# Altium schematic sheet LED16_IPM.SchDoc
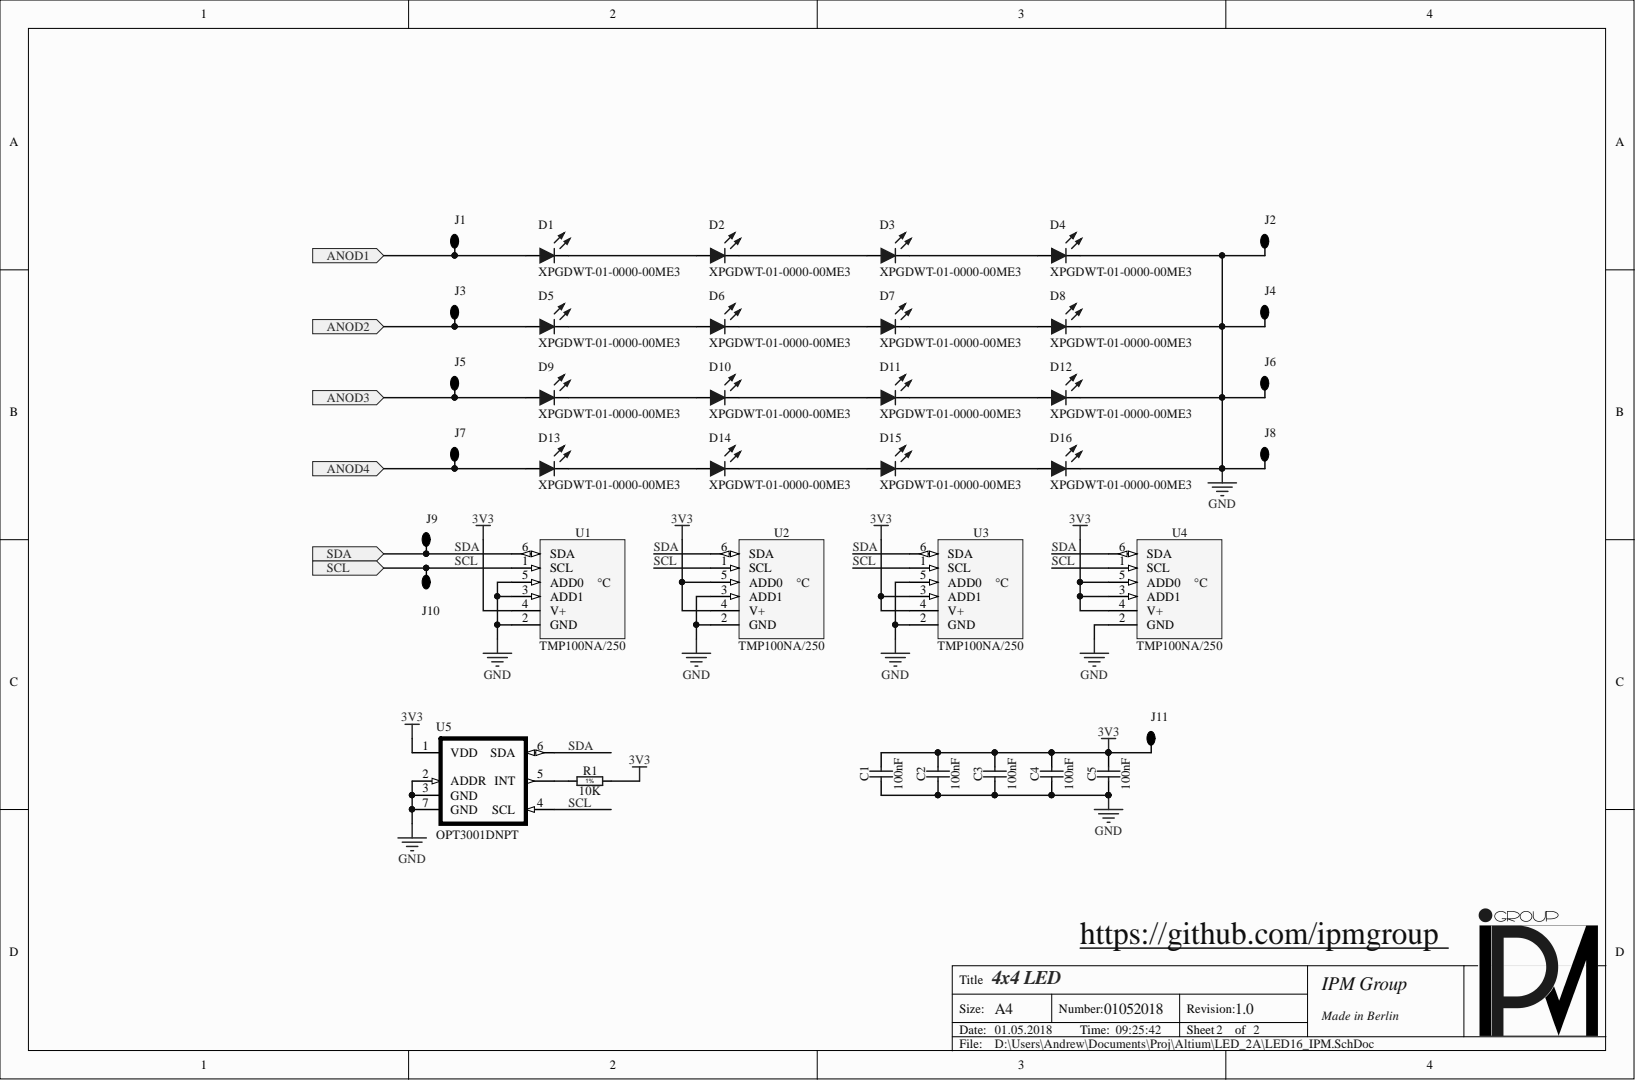
[diagram p0: LED16_IPM.SchDoc]

## Parts
C1  100nF  [CAPC1005X55N]
C2  100nF  [CAPC1005X55N]
C3  100nF  [CAPC1005X55N]
C4  100nF  [CAPC1005X55N]
C5  100nF  [CAPC1005X55N]
D1  XPGDWT-01-0000-00ME3  [LED_XP-G3]
D2  XPGDWT-01-0000-00ME3  [LED_XP-G3]
D3  XPGDWT-01-0000-00ME3  [LED_XP-G3]
D4  XPGDWT-01-0000-00ME3  [LED_XP-G3]
D5  XPGDWT-01-0000-00ME3  [LED_XP-G3]
D6  XPGDWT-01-0000-00ME3  [LED_XP-G3]
D7  XPGDWT-01-0000-00ME3  [LED_XP-G3]
D8  XPGDWT-01-0000-00ME3  [LED_XP-G3]
D9  XPGDWT-01-0000-00ME3  [LED_XP-G3]
D10  XPGDWT-01-0000-00ME3  [LED_XP-G3]
D11  XPGDWT-01-0000-00ME3  [LED_XP-G3]
D12  XPGDWT-01-0000-00ME3  [LED_XP-G3]
D13  XPGDWT-01-0000-00ME3  [LED_XP-G3]
D14  XPGDWT-01-0000-00ME3  [LED_XP-G3]
D15  XPGDWT-01-0000-00ME3  [LED_XP-G3]
D16  XPGDWT-01-0000-00ME3  [LED_XP-G3]
J1  2 way net tie junction  [J_pin_1_5x1_5RS]  (value not drawn)  (no BOM)
J2  2 way net tie junction  [J_pin_1_5x1_5RS]  (value not drawn)  (no BOM)
J3  2 way net tie junction  [J_pin_1_5x1_5RS]  (value not drawn)  (no BOM)
J4  2 way net tie junction  [J_pin_1_5x1_5RS]  (value not drawn)  (no BOM)
J5  2 way net tie junction  [J_pin_1_5x1_5RS]  (value not drawn)  (no BOM)
J6  2 way net tie junction  [J_pin_1_5x1_5RS]  (value not drawn)  (no BOM)
J7  2 way net tie junction  [J_pin_1_5x1_5RS]  (value not drawn)  (no BOM)
J8  2 way net tie junction  [J_pin_1_5x1_5RS]  (value not drawn)  (no BOM)
J9  2 way net tie junction  [J_pin_1_5x1_5RS]  (value not drawn)  (no BOM)
J10  2 way net tie junction  [J_pin_1_5x1_5RS]  (value not drawn)  (no BOM)
J11  2 way net tie junction  [J_pin_1_5x1_5RS]  (value not drawn)  (no BOM)
R1  10K  [RESC1005X40N]
U1  TMP100NA/250  [SOT95P280X145-6N]
U2  TMP100NA/250  [SOT95P280X145-6N]
U3  TMP100NA/250  [SOT95P280X145-6N]
U4  TMP100NA/250  [SOT95P280X145-6N]
U5  OPT3001DNPT  [DNP0006A]

## Ports
ANOD1, ANOD2, ANOD3, ANOD4, SCL, SDA

## Nets
3V3: C1.2 C2.2 C3.2 C4.2 C5.2 J11.1 R1.2 U1.4(V+) U2.4(V+) U2.5(ADD0) U3.3(ADD1) U3.4(V+) U4.3(ADD1) U4.4(V+) U4.5(ADD0) U5.1(VDD)
GND: C1.1 C2.1 C3.1 C4.1 C5.1 D4.2 D8.2 D12.2 D16.2 J2.1 J4.1 J6.1 J8.1 U1.2(GND) U1.3(ADD1) U1.5(ADD0) U2.2(GND) U2.3(ADD1) U3.2(GND) U3.5(ADD0) U4.2(GND) U5.2(ADDR) U5.3(GND) U5.7(GND)
ANOD1: D1.1 J1.1 ; port ANOD1
ANOD2: D5.1 J3.1 ; port ANOD2
ANOD3: D9.1 J5.1 ; port ANOD3
ANOD4: D13.1 J7.1 ; port ANOD4
SCL: J10.1 U1.1(SCL) U2.1(SCL) U3.1(SCL) U4.1(SCL) U5.4(SCL) ; port SCL
SDA: J9.1 U1.6(SDA) U2.6(SDA) U3.6(SDA) U4.6(SDA) U5.6(SDA) ; port SDA
NetD1_2: D1.2 D2.1
NetD2_2: D2.2 D3.1
NetD3_2: D3.2 D4.1
NetD5_2: D5.2 D6.1
NetD6_2: D6.2 D7.1
NetD7_2: D7.2 D8.1
NetD9_2: D9.2 D10.1
NetD10_2: D10.2 D11.1
NetD11_2: D11.2 D12.1
NetD13_2: D13.2 D14.1
NetD14_2: D14.2 D15.1
NetD15_2: D15.2 D16.1
NetR1_1: R1.1 U5.5(INT)

## Library data of the parts
C1-C5 = (no symbol name): CAP, CERM, 0402, 0.1 µF, 16 V, ± 20%, X7R; Manufacturer: KEMET; Manufacturer Part Number: C0402C104M4RACAUTO; Voltage: 16V; Case: 0402; Component Kind: Standard; Library Name: Capacitor.SchLib; Manufacturer1 ComponentHeight: 0.55mm; Mounted: Yes; Part Description: CAP, CERM, 0402, 0.1 µF, 16 V, ± 20%, X7R; Part Number: C0402C104M4RACAUTO; Pin Count: 2; SMD: Yes; Supplier 1: Farnell; Supplier 2: RSComponents; Supplier 3: Digi-Key; Supplier Part Number 1: 2773075; TC: X7R; Tolerance: ±20%; Value: 100nF; Author: CERN DEM JLC
D1-D16 = LED_XP-G3: High Brightness LED, XLamp XP-G3 Series, Neutral White, 125 °, 172 lm, 5000 K, 2 A; Manufacturer: CREE; Component Kind: Standard; Library Name: My_PCB.SchLib; Manufacturer1 ComponentHeight: 2.26mm; Manufacturer Part Number: XPGDWT-01-0000-00ME3; Mounted: Yes; PackageDescription: 3.45 x 3.45 x 2.26 mm; Part Description: High Brightness LED, XLamp XP-G3 Series, Neutral White, 125 °, 172 lm, 5000 K, 2 A; Part Number: XPGDWT-01-0000-00ME3; Pin Count: 2; Price: 1,21 €; Signal Integrity: LED; Simulation: LED3; SMD: Yes; Supplier 1: Farnell; Supplier 2: RSComponents; Supplier 3: Digi-Key; Supplier Part Number 1: 2839927
J1-J11 = 1way: 1 way net tie junction; Library Name: My_PCB.SchLib
R1 = (no symbol name): RES, 10 kohm, 50 V, 0402, 63 mW; Manufacturer: MULTICOMP; Manufacturer Part Number: MCMR04X1002FTL; Power: 0.0625W; TC: ±100ppm/°C; Tolerance: ±1%; Case: 0402; Component Kind: Standard; Library Name: Resistor.SchLib; Manufacturer1 ComponentHeight: 0.4mm; Mounted: Yes; Part Description: RES, 10 kohm, 50 V, 0402, 63 mW; Part Number: MCMR04X1002FTL; Pin Count: 2; SMD: Yes; Supplier 1: Farnell; Supplier 2: RSComponents; Supplier 3: Digi-Key; Supplier Part Number 1: 2072517; Value: 10K; Author: CERN DEM JLC
U1-U4 = TMP100NA/250: Digital Temperature Sensor with I2C Interface; Manufacturer: TEXAS INSTRUMENTS; Part Description: -55°C to +125°C, ±3.0°C Accuracy, Digital Temperature Sensor with I2C Interface; Database Table Name: Sensors; Case: SOT23-6; Component Kind: Standard; Library Name: ICs And Semiconductors.DbLib; Manufacturer Part Number: TMP100NA/250; Mounted: Yes; PackageDescription: SOT23, 6-Leads, Body 1.6x3.0mm, Pitch 0.95mm, IPC Medium Density; Part Number: TMP100NA/250; Pin Count: 6; SMD: Yes; Supplier 1: Farnell; Supplier 2: RSComponents; Supplier 3: Digi-Key; Supplier Part Number 1: 1652476; Author: CERN DEM JLC
U5 = OPT3001DNPT: Special Function IC, Ambient Light Sensor, 1.6 V to 3.6 V in, USON-6; Manufacturer: Texas Instruments; Pitch: 0.65mm; Case: USON-6; Component Kind: Standard; Library Name: My_PCB.SchLib; Manufacturer1 ComponentHeight: 0.65mm; Manufacturer Part Number: OPT3001DNPT; Mounted: Yes; PackageDescription: USON-6; Part Description: Special Function IC, Ambient Light Sensor, 1.6 V to 3.6 V in, USON-6; Part Number: OPT3001DNPT; Pin Count: 6; Price: 2,64 €; SMD: Yes; Supplier 1: Farnell; Supplier 2: RSComponents; Supplier 3: Digi-Key; Supplier Part Number 1: 2466963; Supplier Part Number 2: 900-9810; Supplier Part Number 3: 296-38667-1-ND
